# Altium schematic sheet actuator1.SchDoc
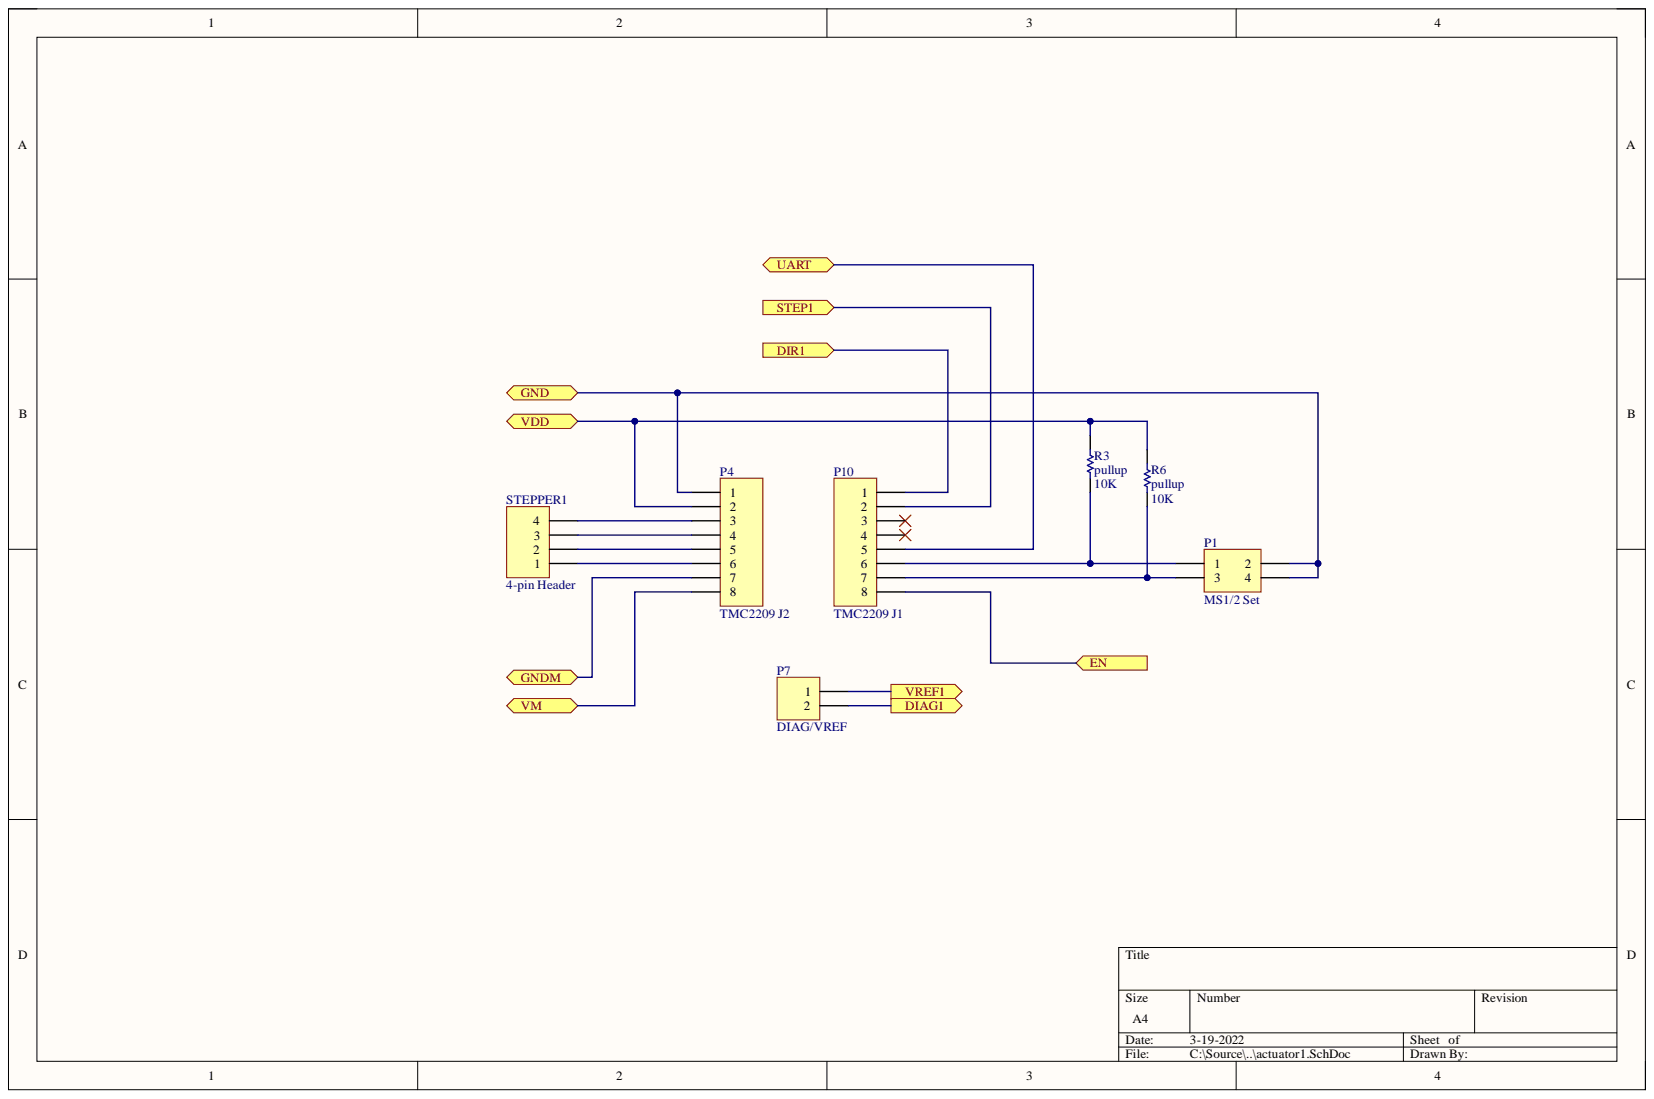
[diagram p0: actuator1.SchDoc]

## Parts
P1  MS1/2 Set  [HDR2X2]
P4  TMC2209 J2  [HDR1X8]
P7  DIAG/VREF  [HDR1X2]
P10  TMC2209 J1  [HDR1X8]
R3  pullup  value 10K  [AXIAL-0.3]
R6  pullup  value 10K  [AXIAL-0.3]
STEPPER1  4-pin Header  [HDR1X4]

## Ports
DIAG1, DIR1, EN, GND, GNDM, STEP1, UART, VDD, VM, VREF1

## Nets
DIAG1: P7.2 ; port DIAG1
DIR1: P10.1 ; port DIR1
EN: P10.8 ; port EN
GND: P1.2 P1.4 P4.1 ; port GND
GNDM: P4.7 ; port GNDM
STEP1: P10.2 ; port STEP1
UART: P10.5 ; port UART
VDD: P4.2 R3.2 R6.2 ; port VDD
VM: P4.8 ; port VM
VREF1: P7.1 ; port VREF1
NetP1_1: P1.1 P10.6 R3.1
NetP1_3: P1.3 P10.7 R6.1
NetP4_3: P4.3 STEPPER1.4
NetP4_4: P4.4 STEPPER1.3
NetP4_5: P4.5 STEPPER1.2
NetP4_6: P4.6 STEPPER1.1

Not connected: P10 3 4

## Library data of the parts
P1 = Header 2X2: Header, 2-Pin, Dual row; Component Kind: Standard; LatestRevisionDate: 17-Jul-2002; LatestRevisionNote: Re-released for DXP Platform.; Library Name: Miscellaneous Connectors.IntLib; Signal Integrity: Connector; Publisher: Altium Limited
P4 P10 = Header 8: Header, 8-Pin; Component Kind: Standard; LatestRevisionDate: 17-Jul-2002; LatestRevisionNote: Re-released for DXP Platform.; Library Name: miscellaneous_connectors.IntLIb; Signal Integrity: Connector; Publisher: Altium Limited
P7 = Header 2: Header, 2-Pin; Component Kind: Standard; LatestRevisionDate: 17-Jul-2002; LatestRevisionNote: Re-released for DXP Platform.; Library Name: miscellaneous_connectors.IntLIb; Signal Integrity: Connector; Publisher: Altium Limited
R3 R6 = Res1: Resistor; Component Kind: Standard; LatestRevisionDate: 17-Jul-2002; LatestRevisionNote: Re-released for DXP Platform.; Library Name: Miscellaneous Devices.IntLib; PackageDescription: Axial Device, Thru-Hole; 2 Leads; 0.3 in Pin Spacing; PackageReference: AXIAL-0.3; Published: 8-Jun-2000; Signal Integrity: Res; Simulation: RESISTOR; Publisher: Altium Limited
STEPPER1 = Header 4: Header, 4-Pin; Component Kind: Standard; LatestRevisionDate: 17-Jul-2002; LatestRevisionNote: Re-released for DXP Platform.; Library Name: Miscellaneous Connectors.IntLib; Signal Integrity: Connector; Publisher: Altium Limited
